# Altium schematic sheet Schematic.SchDoc
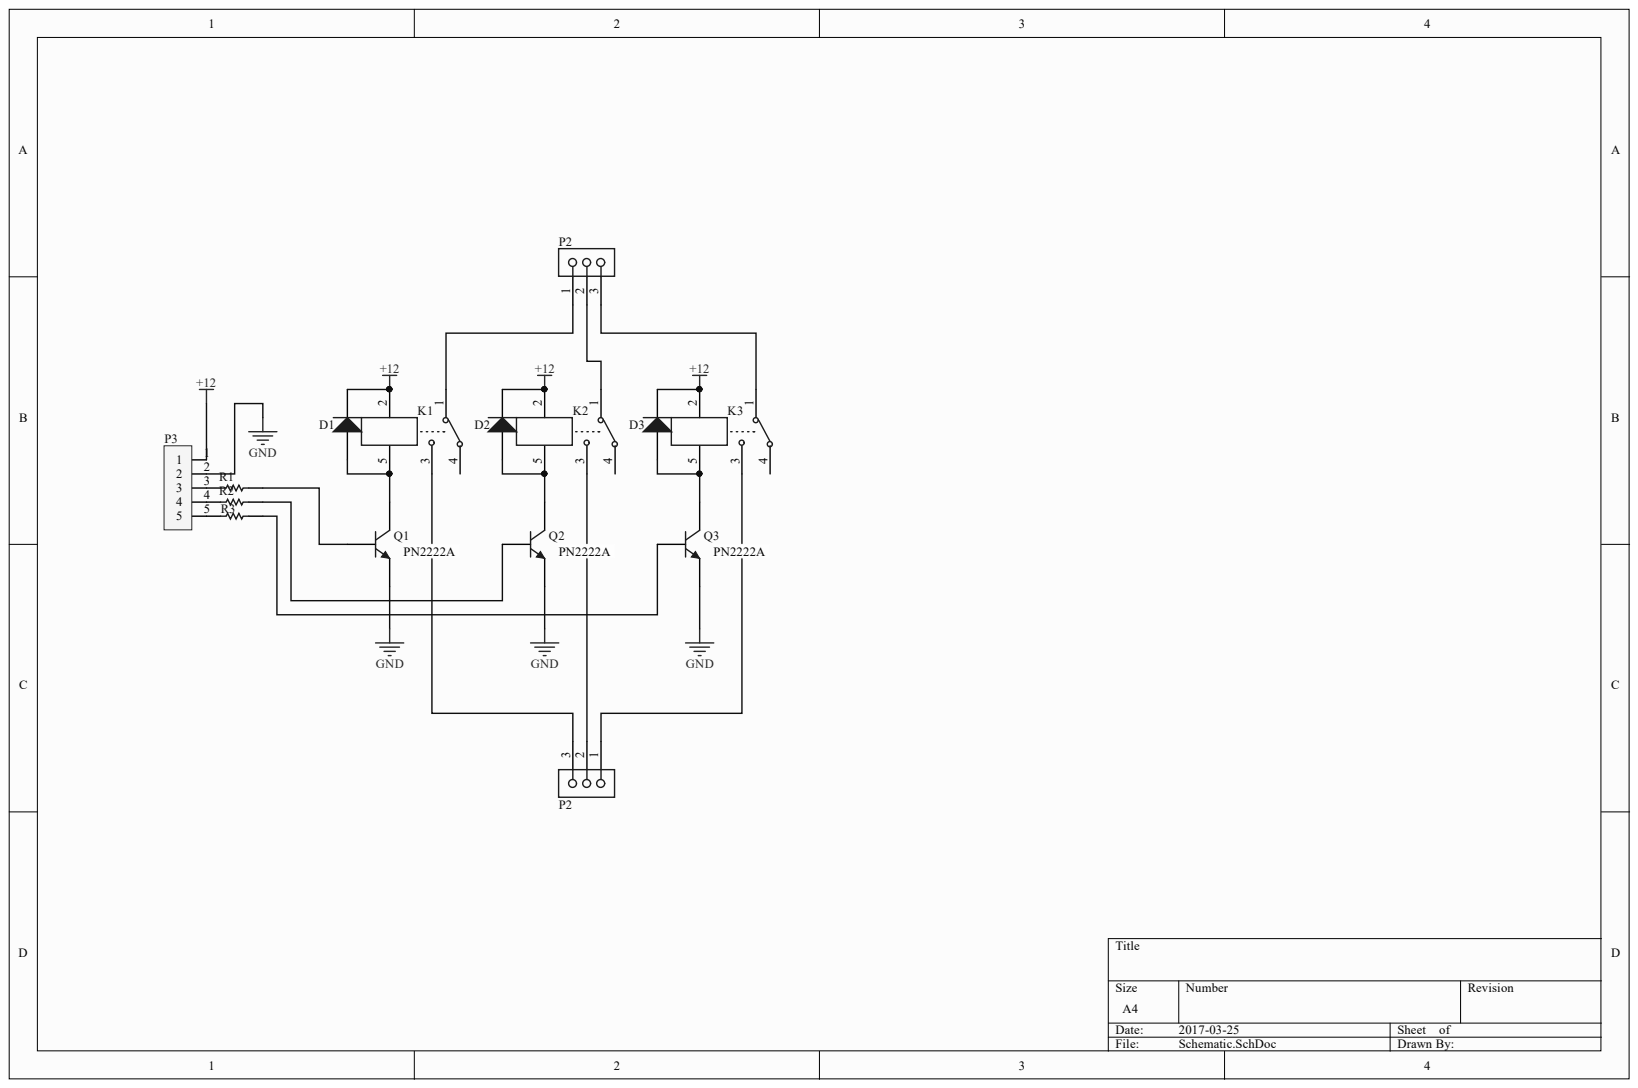
[diagram p0: Schematic.SchDoc]

## Parts
D1  1N4007-T  [DIOD-DO-41-P-2_V]  (value not drawn)
D2  1N4007-T  [DIOD-DO-41-P-2_V]  (value not drawn)
D3  1N4007-T  [DIOD-DO-41-P-2_V]  (value not drawn)
K1  Omron G5LE-1A4-DC12 Relay  [PCB-2662916-1]  (value not drawn)
K2  Omron G5LE-1A4-DC12 Relay  [PCB-2662916-1]  (value not drawn)
K3  Omron G5LE-1A4-DC12 Relay  [PCB-2662916-1]  (value not drawn)
P2  Phoenix Contact 1729021  [PCB-4mlh9ylxcwg828pz9pwv-1]  (value not drawn)
P2  Phoenix Contact 1729021  [PCB-4mlh9ylxcwg828pz9pwv-1]  (value not drawn)
P3  TE Connectivity / AMP 3-644456-5  [PCB-rnlen50cc5tt6vc22c1r-1]  (value not drawn)
Q1  Fairchild Semiconductor PN2222ATF  [PCB-859355-1]  (value not drawn)
Q2  Fairchild Semiconductor PN2222ATF  [PCB-859355-1]  (value not drawn)
Q3  Fairchild Semiconductor PN2222ATF  [PCB-859355-1]  (value not drawn)
R1  Vishay SFR16S0004701JA500  [PCB-4hlvbvydv5koig2rz41u-1]  (value not drawn)
R2  Vishay SFR16S0004701JA500  [PCB-4hlvbvydv5koig2rz41u-1]  (value not drawn)
R3  Vishay SFR16S0004701JA500  [PCB-4hlvbvydv5koig2rz41u-1]  (value not drawn)

## Nets
+12: D1.2 D2.2 D3.2 K1.2 K2.2 K3.2 P3.1
GND: P3.2 Q1.3 Q2.3 Q3.3
NetD1_1: D1.1 K1.5 Q1.1
NetD2_1: D2.1 K2.5 Q2.1
NetD3_1: D3.1 K3.5 Q3.1
NetK1_1: K1.1 P2.1
NetK1_3: K1.3 P2.3
NetK2_1: K2.1 P2.2
NetK2_3: K2.3 P2.2
NetK3_1: K3.1 P2.3
NetK3_3: K3.3 P2.1
NetP3_3: P3.3 R1.1
NetP3_4: P3.4 R2.1
NetP3_5: P3.5 R3.1
NetQ1_2: Q1.2 R1.2
NetQ2_2: Q2.2 R2.2
NetQ3_2: Q3.2 R3.2

Not connected: K1 4; K2 4; K3 4

## Library data of the parts
D1-D3 = 1N4007-T: 1.0 A Rectifier, 8 pF, 1000 V, -65 to 150 degC, 2-Pin DO-41 Plastic, RoHS, Tape and Reel; Capacitance: 8e-12 F; Current Rating: 1.0 A; Lead-Free Status: Lead Free; Polarity: Standard; Voltage Rating (DC): 1000.0 V; Case/Package: DO-41; Component Kind: Standard; Library Name: Octopart library; Lifecycle Status: Active; Mounting Style: Through Hole; Number of Pins: 2; OctopartID: e80c224be0e437cb; Octopart Url: https://octopart.com/1n4007-t-diodes+inc.-55389582; REACH SVHC Compliance: No SVHC; RoHS: Compliant
K1-K3 = Omron G5LE-1A4-DC12 Relay-1: Omron 12V Relay; CustomComponentID: 1f8ykeeixtlqhnftooij; Component Kind: Standard; Library Name: FavoritesLibrary
P2 P2 = 1729021: Low Printed Ckt 3pos 13.5a 250vac 26-16awg Green Plastic Interlocking Housing 5.0mm Pitch 10.00mm Length; Lead-Free Status: Lead Free; Number of Positions: 3; Pin Pitch: 0.005 m; Color: Green; Component Kind: Standard; Flammability Rating: UL 94 V-0; Housing Color: Green; Housing Material: Nylon; Insulation Material: Nylon; Library Name: Octopart library; Lifecycle Status: Not Listed by Manufacturer; Manufacturer Part Number: 1729021; Mounting Style: PCB, Through Hole, Screw; Number of Rows: 1; OctopartID: 7565bc6f78ceaaa2; Octopart URL: http://octopart.com/1729021-phoenix+contact-10445; RoHS: Compliant; Size-Height: 0.01 m; Size-Length: 0.015 m; Size-Width: 0.0081 m
P3 = 3-644456-5: MTA-100 5 Position Through-Hole Single Row Straight Wire to Board Header; ELV Compliant: Compliant; Gender: Male; Lead-Free Status: Lead Free; Mounting Angle: 180 °; Number of Contacts: 5; Pin Pitch: 2.54 mm; Shrouded: No; Voltage Rating (AC): 250 V; Component Kind: Standard; Contact Material: Copper Alloy; Contact Plating: Tin; Flammability Rating: UL 94 V-0; Housing Color: Natural; Housing Material: Polyester; Library Name: Octopart library; Lifecycle Status: Active; Manufacturer Part Number: 3-644456-5; Mounting Style: Through Hole; Number of Positions: 5; Number of Rows: 1; OctopartID: b5d67e8662e76a11; Octopart URL: http://octopart.com/3-644456-5-te+connectivity+%2F+amp-39514711; Orientation: Vertical; Packaging: Box, Bag; RoHS: Compliant; Size-Height: 2.54 mm; Size-Length: 12.4 mm; Size-Width: 2.34 mm
Q1-Q3 = CMP-859355-1: Trans GP BJT NPN 40V 1A 3-Pin TO-92 T/R; CiivaID: 859355; Collector- Base Voltage VCBO: 75 V; Collector-Emitter Saturation Voltage: 1 V; Configuration: Single; DC Collector/Base Gain hfe Min: 100; DC Current Gain hFE Max: 300; Emitter- Base Voltage VEBO: 6 V; Gain Bandwidth Product fT: 300 MHz; Maximum Power Dissipation: 625 mW; Unit Weight: 0.008466 oz; <PCN>: http://www.mouser.com/PCN/3.26.10 LTBD Fairchild.pdf; Brand: Fairchild Semiconductor; Ciiva URL: https://ciiva.com/part/pn2222atf-859355; Collector- Emitter Voltage VCEO Max: 40 V; Component Kind: Standard; Continuous Collector Current: 1 A; Factory Pack Quantity: 2000; Library Name: CommunityVault; Manufacturer: Fairchild Semiconductor; Manufacturer Part Number: PN2222ATF; Maximum DC Collector Current: 1 A; Maximum Operating Temperature: + 150 C; Minimum Operating Temperature: - 55 C; Mounting Style: Through Hole; Package / Case: TO-92-3 Kinked Lead; Packaging: Reel; Product Category: Transistors Bipolar - BJT; RoHS: Details; Series: PN2222; Transistor Polarity: NPN
R1 = CMP-37f3fc6db5dfe834-1: Through Hole Metal Film Resistor, SFR16S Series, 4.7 kohm, 500 mW, - 5%, 200 V, Axial Leaded; Lead-Free Status: Lead Free; Lead Length: 0.026 m; Power Rating: 0.5 W; Resistance: 4700.0 Ω; Voltage Rating (DC): 200.0 V; Component Kind: Standard; Library Name: CommunityVault; Manufacturer Part Number: SFR16S0004701JA500; Mounting Style: Through Hole; Number of Pins: 2; OctopartID: 37f3fc6db5dfe834; Octopart URL: http://octopart.com/sfr16s0004701ja500-vishay-43296586; REACH SVHC Compliance: No SVHC; Resistance Tolerance: ±5%; RoHS: Compliant
R2 R3 = SFR16S0004701JA500: Through Hole Metal Film Resistor, SFR16S Series, 4.7 kohm, 500 mW, - 5%, 200 V, Axial Leaded; Lead-Free Status: Lead Free; Lead Length: 0.026 m; Power Rating: 0.5 W; Resistance: 4700.0 Ω; Voltage Rating (DC): 200.0 V; Component Kind: Standard; Library Name: Octopart library; Manufacturer Part Number: SFR16S0004701JA500; Mounting Style: Through Hole; Number of Pins: 2; OctopartID: 37f3fc6db5dfe834; Octopart URL: http://octopart.com/sfr16s0004701ja500-vishay-43296586; REACH SVHC Compliance: No SVHC; Resistance Tolerance: ±5%; RoHS: Compliant
